# Altium schematic sheet Phase_Measurements.SchDoc
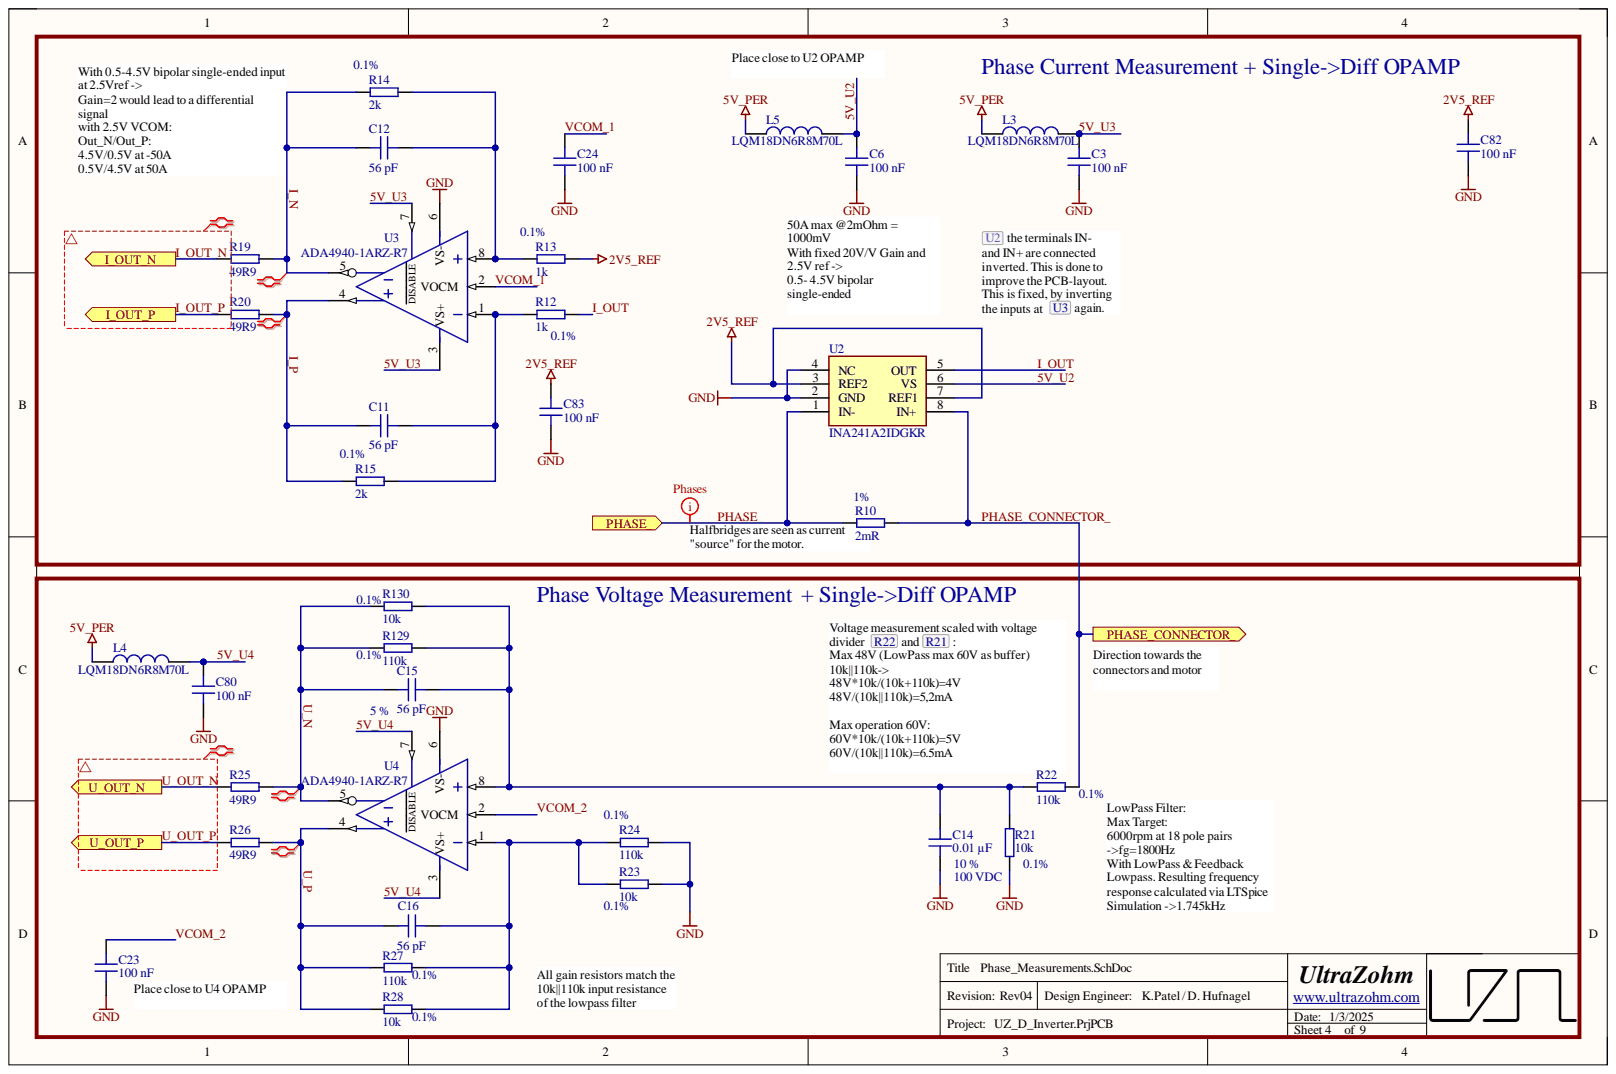
[diagram p0: Phase_Measurements.SchDoc]

## Parts
C3  100 nF  [C_0603]
C6  100 nF  [C_0603]
C11  56 pF  [C_0603]
C12  56 pF  [C_0603]
C14  0.01 µF  Tolerance: 10 %  [C_0805]
C15  56 pF  Tolerance: 5 %  [C_0603]
C16  56 pF  [C_0603]
C23  100 nF  [C_0603]
C24  100 nF  [C_0603]
C80  100 nF  [C_0603]
C82  100 nF  [C_0603]
C83  100 nF  [C_0603]
L3  LQM18DN6R8M70L  [INDC1608X95N]
L4  LQM18DN6R8M70L  [INDC1608X95N]
L5  LQM18DN6R8M70L  [INDC1608X95N]
R10  2mR  Tolerance: 1%  [3921]
R12  1k  Tolerance: 0.1%  [RES 0603_1608]
R13  1k  Tolerance: 0.1%  [RES 0603_1608]
R14  2k  Tolerance: 0.1%  [RES 0603_1608]
R15  2k  Tolerance: 0.1%  [RES 0603_1608]
R19  49R9  [RES 0603_1608]
R20  49R9  [RES 0603_1608]
R21  10k  Tolerance: 0.1%  [RES 0603_1608]
R22  110k  Tolerance: 0.1%  [RES 0603_1608]
R23  10k  Tolerance: 0.1%  [RES 0603_1608]
R24  110k  Tolerance: 0.1%  [RES 0603_1608]
R25  49R9  [RES 0603_1608]
R26  49R9  [RES 0603_1608]
R27  110k  Tolerance: 0.1%  [RES 0603_1608]
R28  10k  Tolerance: 0.1%  [RES 0603_1608]
R129  110k  Tolerance: 0.1%  [RES 0603_1608]
R130  10k  [RES 0603_1608]
U2  INA241A2IDGKR  [SOP65P490X110-8N]
U3  ADA4940-1ARZ-R7  [SOT127P600X175-8N]
U4  ADA4940-1ARZ-R7  [SOT127P600X175-8N]

## Ports
I_OUT_N, I_OUT_P, PHASE_, PHASE_CONNECTOR_, U_OUT_N, U_OUT_P

## Nets
2V5_REF: C82.2 C83.2 R13.2 U2.3(REF2) U2.7(REF1)
5V_PER: L3.1 L4.1 L5.1
GND: C3.1 C6.1 C14.1 C23.1 C24.1 C80.1 C82.1 C83.1 R21.1 R23.1 R24.2 U2.2(GND) U2.4(NC) U3.6(VS-) U4.6(VS-)
5V_U2: C6.2 L5.2 U2.6(VS)
5V_U3: C3.2 L3.2 U3.3(VS+) U3.7
5V_U4: C80.2 L4.2 U4.3(VS+) U4.7
I_N: C12.1 R14.1 R19.2 U3.5
I_OUT: R12.2 U2.5(OUT)
I_OUT_N: R19.1 ; port I_OUT_N
I_OUT_P: R20.1 ; port I_OUT_P
I_P: C11.1 R15.1 R20.2 U3.4
PHASE_: R10.1 U2.1(IN-) ; port PHASE_
PHASE_CONNECTOR_: R10.2 R22.2 U2.8(IN+) ; port PHASE_CONNECTOR_
U_N: C15.1 R25.2 R129.1 R130.2 U4.5
U_OUT_N: R25.1 ; port U_OUT_N
U_OUT_P: R26.1 ; port U_OUT_P
U_P: C16.1 R26.2 R27.1 R28.2 U4.4
VCOM_1: C24.2 U3.2(VOCM)
VCOM_2: C23.2 U4.2(VOCM)
NetC11_2: C11.2 R12.1 R15.2 U3.1
NetC12_2: C12.2 R13.1 R14.2 U3.8
NetC14_2: C14.2 C15.2 R21.2 R22.1 R129.2 R130.1 U4.8
NetC16_2: C16.2 R23.2 R24.1 R27.2 R28.1 U4.1

## Library data of the parts
C3 C6 C23 C24 C80 C82 C83 = C1608X7S2A104KT000N: 100V 100nF X7S ±10% 0603 Multilayer Ceramic Capacitors MLCC - SMD/SMT ROHS; Manufacturer: TDK; Created from: AGeiger; Database Table Name: Capacitors - SMD; Voltage Rating: 100 VDC; Component Kind: Standard; Component Type: Capacitor 0603; ComponentLink1Description: Datasheet; ComponentLink1URL: https://datasheet.lcsc.com/lcsc/2304140030_TDK-C1608X7S2A104KT000N_C343025.pdf; Created On: 20.12.2020 13:54:13; Device Package: 0603; Last Updated: 14.12.2022 12:14:21; LCSC Part Number: C343025; Library Name: user.DbLib; Live Cycle Status: 1; Manufacturer Part Number: C1608X7S2A104KT000N; Mounting Type: SMD; Operating Temperature: -55 to 125°C; PartID: 148; Series: X7R; Stock number: not stored; Supplier 1: LCSC; Supplier Part Number 1: C343025; Tolerance: 10 %; Type: Capacitor; Value: 100 nF
C11 C12 C16 = CL10C560JB8NNNC: Capacitor 0603, 50 VDC, 56 pF, C0G; Manufacturer: Samsung Electro-Mechanics; Created from: DHufnagel; Database Table Name: Capacitors - SMD; Tolerance: 5 %; Voltage Rating: 50 VDC; Component Kind: Standard; Component Type: Capacitor 0603; ComponentLink1Description: Datasheet; ComponentLink1URL: https://www.mouser.de/datasheet/2/585/MLCC-1837944.pdf; Created On: 20.12.2020 13:54:13; Device Package: 0603; Last Updated: 20.12.2020 16:24:16; LCSC Part Number: C39148; Library Name: user.DbLib; Live Cycle Status: 1; Manufacturer Part Number: CL10C560JB8NNNC; Mounting Type: SMD; Operating Temperature: -55 - 125°C; PartID: 92; Series: CL; Stock number: not stored; Supplier 1: Mouser; Supplier 2: LCSC; Supplier Part Number 1: 187-CL10C560JB8NNNC; Supplier Part Number 2: C39148; Type: Capacitor; Value: 56 pF
C14 = HV0805X7R103K101NT: 100V 10nF 0805 Multilayer Ceramic Capacitors MLCC - SMD/SMT ROHS; Manufacturer: AIDE; Created from: KIlhan; Database Table Name: Capacitors - SMD; Voltage Rating: 100 VDC; Component Kind: Standard; Component Type: Capacitor 0805; ComponentLink1Description: Datasheet; ComponentLink1URL: https://datasheet.lcsc.com/lcsc/2103311437_AIDE-HV1210X7R332K202NT_C2691813.pdf; Created On: 05.07.2023 15:42:28; Device Package: 0805; Last Updated: 05.07.2023 15:42:28; LCSC Part Number: C2691724; Library Name: user.DbLib; Live Cycle Status: 1; Manufacturer Part Number: HV0805X7R103K101NT; Mounting Type: SMD; Operating Temperature: -55 - 125°C; PartID: 128; Series: C; Stock number: not stored; Supplier 1: JLCPCB; Supplier Part Number 1: HV0805X7R103K101NT; Type: Capacitor; Value: 0.01 µF
C15 = CL10C560JB8NNNC: Capacitor 0603, 50 VDC, 56 pF, C0G; Manufacturer: Samsung Electro-Mechanics; Created from: DHufnagel; Database Table Name: Capacitors - SMD; Voltage Rating: 50 VDC; Component Kind: Standard; Component Type: Capacitor 0603; ComponentLink1Description: Datasheet; ComponentLink1URL: https://www.mouser.de/datasheet/2/585/MLCC-1837944.pdf; Created On: 20.12.2020 13:54:13; Device Package: 0603; Last Updated: 20.12.2020 16:24:16; LCSC Part Number: C39148; Library Name: user.DbLib; Live Cycle Status: 1; Manufacturer Part Number: CL10C560JB8NNNC; Mounting Type: SMD; Operating Temperature: -55 - 125°C; PartID: 92; Series: CL; Stock number: not stored; Supplier 1: Mouser; Supplier 2: LCSC; Supplier Part Number 1: 187-CL10C560JB8NNNC; Supplier Part Number 2: C39148; Type: Capacitor; Value: 56 pF
L3-L5 = LQM18DN6R8M70L: 6.8µH, 120mA, 0603, 740mOhm, 20%; Manufacturer: Murata; MPN: B82464G4154M; Created from: DHufnagel; Database Table Name: Inductances - SMD; DCR: 320m; MF: EPCOS / TDK; Rated Current: 0.85 A; Type: fixed inductor; Value: 6.8uH; Component Kind: Standard; Component Type: SMD fixed inductor; ComponentLink1Description: Datasheet; ComponentLink1URL: https://www.mouser.de/datasheet/2/281/1/JELF243B_0086-2298241.pdf; Created On: 19.07.2022 15:47:17; DC current: 120mA; Device Package: SMD; Last Updated: 15.06.2023 16:03:16; LCSC Part Number: C1881372; Library Name: user.DbLib; Live Cycle Status: 1; Manufacturer Part Number: LQM18DN6R8M70L; Mounting Type: SMD; Operating Temperature: -40 - 125°C; PartID: 10; Series: LQM18DN_70; Stock number: not stored; Supplier 1: Mouser; Supplier 2: Digi-Key; Supplier Part Number 1: 81-LQM18DN6R8M70L; Supplier Part Number 2: 490-LQM18DN6R8M70LCT-ND
R10 = WSL39212L000FEA: Current Sense Resistors - SMD 3watts .002ohms 1%; Manufacturer: Vishay; Created from: AGeiger; Component Kind: Standard; Component Type: Shunt SMD resistor; ComponentLink1Description: Datasheet; ComponentLink1URL: https://www.vishay.com/docs/30110/wsl3921-wsl5931.pdf; Created On: 05.03.2024 08:52:09; Database Table Name: Resistors - SMD; Device Package: 3921; Last Updated: 05.03.2024 08:53:02; LCSC Part Number: C844494; Library Name: user.DbLib; Live Cycle Status: 1; Manufacturer Part Number: WSL39212L000FEA; Mounting Type: SMD; PartID: 327; Supplier 1: Mouser; Supplier 2: LCSC; Supplier Part Number 1: 71-WSL39212L000FEA; Supplier Part Number 2: C844494; Type: Shunt; Value: 2mR
R12 R13 = PCF-W0603LF-03-1001-B-P-LT: SMD resistor 0.1%; Manufacturer: IRC / TT Electronics; Created from: MGremer; Database Table Name: Resistors - SMD; Component Kind: Standard; Component Type: SMD resistor; ComponentLink1Description: Datasheet; ComponentLink1URL: https://www.mouser.de/datasheet/2/414/PCF-1528243.pdf; Created On: 14.12.2020 16:17:14; Device Package: 0603; Last Updated: 21.02.2024 15:45:55; LCSC Part Number: C110776; Library Name: user.DbLib; Live Cycle Status: 1; Manufacturer Part Number: PCF-W0603LF-03-1001-B-P-LT; Mounting Type: SMD; PartID: 163; Stock number: not stored; Supplier 1: Mouser; Supplier Part Number 1: 66-PCFW0603LF031001B; Type: Resistor; Value: 1k
R14 R15 = ERJ-PB3B2001V: SMD resistor 0.1%; Manufacturer: Panasonic; Created from: DHufnagel; Database Table Name: Resistors - SMD; Component Kind: Standard; Component Type: SMD resistor; ComponentLink1Description: Datasheet; ComponentLink1URL: https://www.mouser.de/datasheet/2/315/AOA0000C328-1530132.pdf; Created On: 14.12.2020 16:17:14; Device Package: 0603; Last Updated: 02.03.2021 14:07:32; LCSC Part Number: C189729; Library Name: user.DbLib; Live Cycle Status: 1; Manufacturer Part Number: ERJ-PB3B2001V; Mounting Type: SMD; PartID: 215; Stock number: not stored; Supplier 1: Mouser; Supplier 2: LCSC; Supplier Part Number 1: 667-ERJ-PB3B2001V; Supplier Part Number 2: C189729; Type: Resistor; Value: 2k
R19 R20 R25 R26 = RK73H1JTTD49R9F: SMD resistor 1%; Manufacturer: KOA Speer; Created from: DHufnagel; Database Table Name: Resistors - SMD; Component Kind: Standard; Component Type: SMD resistor; ComponentLink1Description: Datasheet; ComponentLink1URL: https://www.mouser.de/datasheet/2/219/RK73H-1825326.pdf; Created On: 14.12.2020 16:17:14; Device Package: 0603; Last Updated: 27.05.2022 13:46:35; LCSC Part Number: C160046; Library Name: user.DbLib; Live Cycle Status: 1; Manufacturer Part Number: RK73H1JTTD49R9F; Mounting Type: SMD; PartID: 214; Stock number: not stored; Supplier 1: Mouser; Supplier 2: LCSC; Supplier Part Number 1: 660-RK73H1JTTD49R9F; Supplier Part Number 2: C160046; Tolerance: 1%; Type: Resistor; Value: 49R9
R21 R23 R28 R130 = RQ73C1J10KBTD: SMD resistor 0.1%, 10ppm; Manufacturer: TE Connectivity; Created from: DHufnagel; Database Table Name: Resistors - SMD; Component Kind: Standard; Component Type: SMD resistor; ComponentLink1Description: Datasheet; ComponentLink1URL: https://www.mouser.de/datasheet/2/418/5/ENG_DS_1773270_2_B-1533717.pdf; Created On: 14.12.2020 16:17:14; Device Package: 0603; Last Updated: 21.02.2024 15:51:17; LCSC Part Number: C95204; Library Name: user.DbLib; Live Cycle Status: 1; Manufacturer Part Number: RQ73C1J10KBTD; Mounting Type: SMD; PartID: 231; Stock number: not stored; Supplier 1: Mouser; Supplier 2: LCSC; Supplier Part Number 1: 279-RQ73C1J10KBTD; Supplier Part Number 2: C95204; Type: Resistor; Value: 10k
  R130: Tolerance: 0.1%
R22 R24 R27 R129 = RQ73C1J110KBTD: SMD resistor 0.1%, 10ppm; Manufacturer: TE Connectivity / Holsworthy; Created from: DHufnagel; Database Table Name: Resistors - SMD; Component Kind: Standard; Component Type: SMD resistor; ComponentLink1Description: Datasheet; ComponentLink1URL: https://www.mouser.de/datasheet/2/418/5/ENG_DS_1773270_2_B-1533717.pdf; Created On: 14.12.2020 16:17:14; Device Package: 0603; Last Updated: 21.02.2024 16:00:52; LCSC Part Number: C326736; Library Name: user.DbLib; Live Cycle Status: 1; Manufacturer Part Number: RQ73C1J110KBTD; Mounting Type: SMD; PartID: 216; Stock number: not stored; Supplier 1: Mouser; Supplier 2: LCSC; Supplier Part Number 1: 279-RQ73C1J110KBTD; Supplier Part Number 2: C326736; Type: Resistor; Value: 110k
U2 = INA241A2IDGKR: Current Sense Amplifier, 5-100 V  CMV, 20 V/V, bidirectional; Manufacturer: Texas Instruments; Channels: 1; CMRR: 89dB; Created from: KPatel; Database Table Name: Logic - Operational Amplifiers; GBP: 100MHz; Value: Rail-to-Rail; Component Kind: Standard; Component Type: Current Sense Amplifier; ComponentLink1Description: Datasheet; ComponentLink1URL: https://www.ti.com/lit/ds/symlink/ina241b.pdf?ts=1718088817119&ref_url=https%253A%252F%252Fwww.mouser.de%252F; Created On: 6/28/2024 9:00:46 AM; Device Package: VSSOP-8; Last Updated: 6/28/2024 9:00:46 AM; Library Name: user.DbLib; Live Cycle Status: 1; Manufacturer Part Number: INA241A2IDGKR; Mounting Type: SMD; Operating Temperature: -40 - 125°C; PartID: 30; Series: INA241A; Stock number: not stored; Supplier 1: Mouser; Supplier Part Number 1: 595-INA241A2IDGKR; Type: Current Sense Amplifier
U3 U4 = ADA4940-1ARZ-R7: Fully Differential Amplifier, Ultralow-Power,Low Distortion, 260-MHz; Manufacturer: Analog Devices; Channels: 1; CMRR: 86 dB; Created from: DHufnagel; Database Table Name: Logic - Operational Amplifiers; GBP: 260 MHz; Component Kind: Standard; Component Type: Differential Amplifier; ComponentLink1Description: Datasheet; ComponentLink1URL: https://www.mouser.de/datasheet/2/609/ada4940_1_4940_2-2955874.pdf; Created On: 18.08.2022 12:53:27; Device Package: SOIC-8; Last Updated: 18.08.2022 13:04:18; LCSC Part Number: C730049; Library Name: user.DbLib; Live Cycle Status: 1; Manufacturer Part Number: ADA4940-1ARZ-R7; Mounting Type: SMD; Operating Temperature: -40 - 105°C; PartID: 14; Series: ADA4940-1; Stock number: not stored; Supplier 1: Mouser; Supplier 2: Digi-Key; Supplier 3: LCSC; Supplier Part Number 1: 584-ADA4940-1ARZ; Supplier Part Number 2: 505-ADA4940-1ARZ-ND; Supplier Part Number 3: C730049; Type: Differential Amplifiers
